# Altium schematic sheet Manometer click.SchDoc
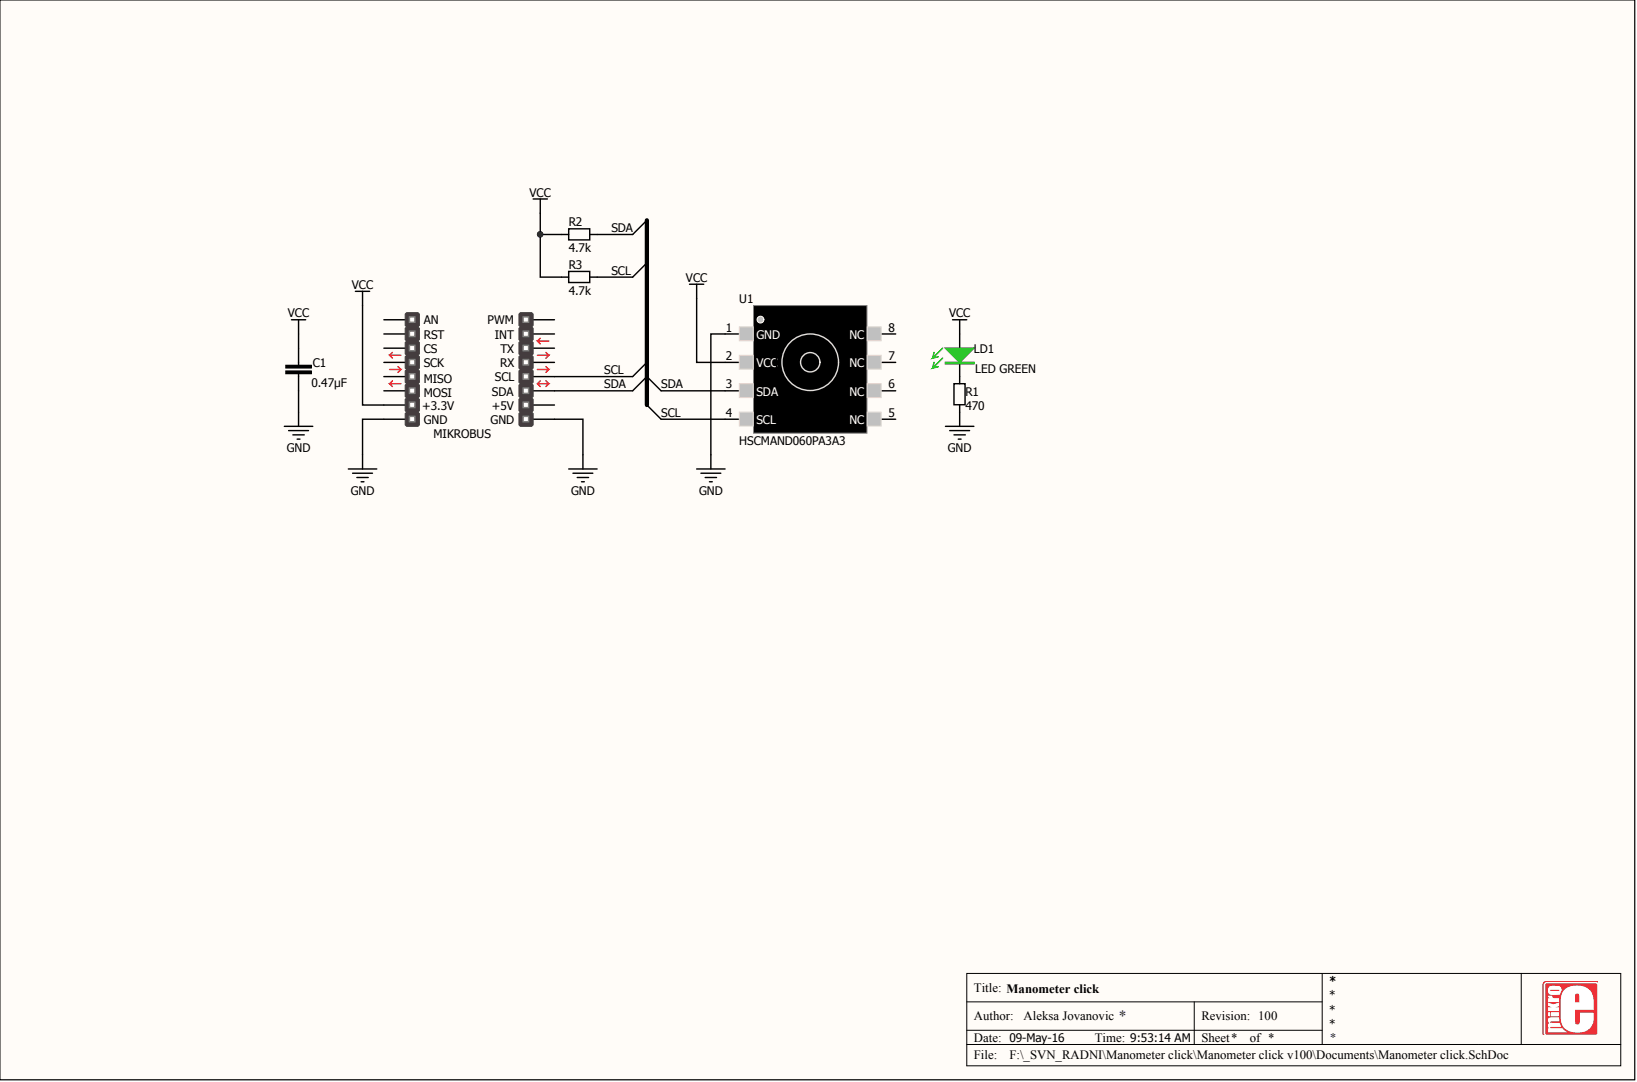
[diagram p0: Manometer click.SchDoc]

## Parts
C1  0.47µF  [CAPC2013X100N-0805-NoOverlay]
LD1  LED GREEN  Family: LED  [DIOGREENC2013X120N-0805-NoOverlay]
MIKROBUS  MIKROBUS DEVICE CONN  [MIKRO_BUS_MINI]  (value not drawn)  (no BOM)
R1  470  [RESC2013X38N-0805-NOOVERLAY]
R2  4.7k  [RESC2013X38N-0805-NOOVERLAY]
R3  4.7k  [RESC2013X38N-0805-NOOVERLAY]
U1  HSCMAND060PA3A3  [SOIC100P530X266-8L0N-NoOverlay]

## Nets
GND: C1.1 MIKROBUS.8(GND) MIKROBUS.9(GND) R1.1 U1.1(GND)
VCC: C1.2 LD1.2 MIKROBUS.7(+3.3V) R2.1 R3.1 U1.2(VCC)
SCL: MIKROBUS.12(SCL) R3.2 U1.4(SCL)
SDA: MIKROBUS.11(SDA) R2.2 U1.3(SDA)
NetLD1_1: LD1.1 R1.2
N00002:

Not connected: MIKROBUS 1(AN) 2(RST) 3(CS) 4(SCK) 5(MISO) 6(MOSI) 10(+5V) 13(RX) 14(TX) 15(INT) 16(PWM); U1 5(NC) 6(NC) 7(NC) 8(NC)

## Library data of the parts
C1 = 2911010048532: CAP CER 0.47UF 50V X7R 0805; Manufacturer: Samsung Electro-Mechanics America, Inc.; Manufacturer Part Number: CL21B474KBFNNNG; Assembly: SMD; Database Table Name: capacitors; id: 108; item_id: 5534; Tolerance: ±10%; Barcode: 2911010048532; Capacitance: 0.47µF; Category: Capacitors; Component Kind: Standard; Datasheet URL: http://www.samsungsem.com/kr/support/product-search/mlcc/CL21B474KBFNNNG.jsp; Family: CERAMIC CAPACITOR; Library Name: SVNDatabase_Libs1.SVNDbLib; Package Case: 0805 (2012 Metric); Part Type: Capacitors; Temperature Coefficient: X7R; Voltage Rated: 50V
LD1 = 2911010024338: LED GREEN CLEAR 0805 SMD; Manufacturer: Kingbright; Manufacturer Part Number: APT2012SGC; Database Table Name: optoelectronics; id: 28; item_id: 3342; Lens Color: Colorless; Voltage Forward: 2.2V; Wavelength: 568nm; Assembly: SMD; Barcode: 2911010024338; Category: Optoelectronics; Color: Green; Component Kind: Standard; Datasheet URL: http://www.kingbrightusa.com/images/catalog/SPEC/APT2012SGC.pdf; Lens Transparency: Clear; Library Name: SVNDatabase_Libs1.SVNDbLib; Package Case: 0805 (2012 Metric); Part Type: Optoelectronics
MIKROBUS = MIKROBUS DEVICE CONN: mikroBUS Target Header; Library Name: ME-Integrated_Library.IntLib
R1 = 0100000007921: RES SMD 470 OHM 1% 1/8W 0805; Manufacturer: Yageo; Manufacturer Part Number: RC0805FR-07470RL; Database Table Name: resistors; id: 207; item_id: 1082; Power: 0.125W, 1/8W; Temperature Coefficient: ±100ppm/°C; Assembly: SMD; Barcode: 0100000007921; Category: Resistors; Component Kind: Standard; Datasheet URL: http://www.yageo.com/NewPortal/yageodocoutput?fileName=/pdf/R-Chip/PYu-RC_Group_51_RoHS_L_3.pdf; Family: RESISTOR; Library Name: SVNDatabase_Libs1.SVNDbLib; Package Case: 0805 (2012 Metric); Part Type: Resistors; Resistance: 470; Tolerance: ±1%
R2 R3 = 0100000002056: RES SMD 4.7K OHM 1% 1/8W 0805; Manufacturer: Yageo; Manufacturer Part Number: RC0805FR-074K7L; Database Table Name: resistors; id: 221; item_id: 470; Power: 0.125W, 1/8W; Temperature Coefficient: ±100ppm/°C; Assembly: SMD; Barcode: 0100000002056; Category: Resistors; Component Kind: Standard; Datasheet URL: http://www.yageo.com/NewPortal/yageodocoutput?fileName=/pdf/R-Chip/PYu-RC_Group_51_RoHS_L_3.pdf; Family: RESISTOR; Library Name: SVNDatabase_Libs1.SVNDbLib; Package Case: 0805 (2012 Metric); Part Type: Resistors; Resistance: 4.7k; Tolerance: ±1%
U1 = 0100000096499: SENSOR PRES 60PSI ABSO 3.3V SMD; Manufacturer: Honeywell Sensing and Productivity Solutions; Accuracy: ±0.25%; Database Table Name: integrated_circuits; id: 574; item_id: 8763; Output: 12 b; Output Type: I²C; Voltage  Supply: 3 V ~ 3.6 V; Assembly: SMD; Barcode: 0100000096499; Category: Sensors, Transducers; Component Kind: Standard; Datasheet URL: http://sensing.honeywell.com/honeywell-sensing-trustability-hsc-series-high-accuracy-board-mount-pressure-sensors-50099148-a-en.pdf; Family: Pressure Sensors; Features: Amplified Output, Temperature Compensated; Library Name: SVNDatabase_Libs1.SVNDbLib; Manufacturer Part Number: HSCMAND060PA3A3; Package Case: 8-SMD, J-Lead, Top Port; Part Type: Integrated Circuits
